# Altium schematic sheet esquematico-sensor-AD5933.SchDoc
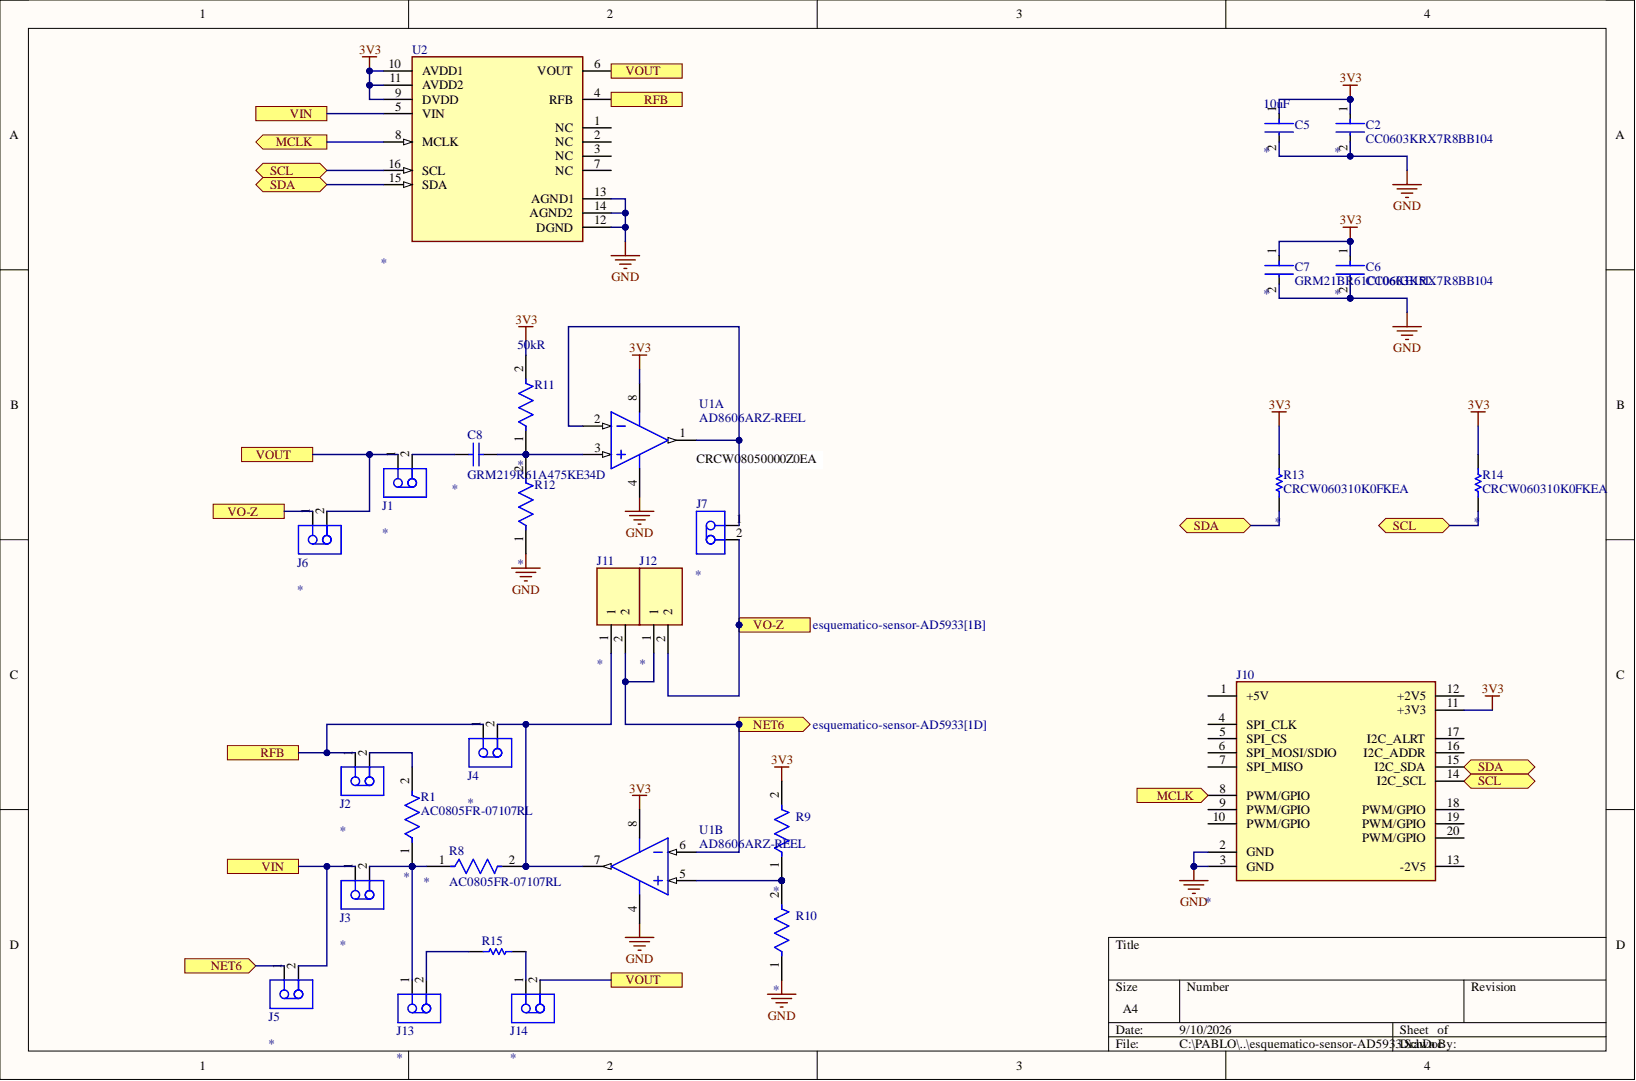
[diagram p0: esquematico-sensor-AD5933.SchDoc]

## Parts
C2  CC0603KRX7R8BB104  [FP-CC0603-DA-MFG]
C5  GRM21BR61C106KE15L  Capacitance: 10uF  [FP-GRM21B-0_1-IPC_C]
C6  CC0603KRX7R8BB104  [FP-CC0603-DA-MFG]
C7  GRM21BR61C106KE15L  [FP-GRM21B-0_1-IPC_C]
C8  GRM219R61A475KE34D  [CAPC2013X95X45LL10T25]
J1  (no value)  [TECO-640456-2_V]
J2  (no value)  [TECO-640456-2_V]
J3  (no value)  [TECO-640456-2_V]
J4  (no value)  [TECO-640456-2_V]
J5  (no value)  [TECO-640456-2_V]
J6  (no value)  [TECO-640456-2_V]
J7  (no value)  [TECO-640456-2_V]
J10  10129382-920002BLF  [Base Board Modular Connector (MALE)]  (value not drawn)
J11  (no value)  [Terminal Block 2-position]
J12  TB0011-350-02BE  [Terminal Block 2-position]  (value not drawn)
J13  (no value)  [TECO-640456-2_V]
J14  (no value)  [TECO-640456-2_V]
R1  AC0805FR-07107RL  [FP-AC0805-MFG]
R8  AC0805FR-07107RL  [FP-AC0805-MFG]
R9  (no value)  [FP-TNPU0603-e3-MFG]
R10  (no value)  [FP-TNPU0603-e3-MFG]
R11  Resistance: 50kR  [FP-TNPU0603-e3-MFG]
R12  (no value)  [FP-TNPU0603-e3-MFG]
R13  CRCW060310K0FKEA  [RESC1609X50X30NL8T20]
R14  CRCW060310K0FKEA  [RESC1609X50X30NL8T20]
R15  CRCW08050000Z0EA  [RESC2013X50X30LL20T20]
U1  AD8606ARZ-REEL  [ADI-R-8_L]  (parts A,B)
U2  AD5933WYRSZ-REEL7  [FP-RS-16-IPC_A]  (value not drawn)

## Ports
MCLK, NET6, RFB, SCL, SDA, VIN, VO-Z, VOUT

## Nets
3V3: C2.1 C5.1 C6.1 C7.1 J10.11(+3V3) R9.2 R11.2 R13.1 R14.2 U1.8 U2.9(DVDD) U2.10(AVDD1) U2.11(AVDD2)
GND: C2.2 C5.2 C6.2 C7.2 J10.2(GND) J10.3(GND) R10.1 R12.1 U1.4 U2.12(DGND) U2.13(AGND1) U2.14(AGND2)
MCLK: J10.8(PWM/GPIO) U2.8(MCLK) ; port MCLK
NET6: J5.1 J11.2 J12.1 U1.6 ; port NET6
RFB: J2.1 J4.1 U2.4(RFB) ; port RFB
SCL: J10.14(I2C_SCL) R14.1 U2.16(SCL) ; port SCL
SDA: J10.15(I2C_SDA) R13.2 U2.15(SDA) ; port SDA
VIN: J3.1 J5.2 U2.5(VIN) ; port VIN
VO-Z: J6.1 J7.2 J12.2 ; port VO-Z
VOUT: J1.1 J6.2 J14.2 U2.6(VOUT) ; port VOUT
NetC8_1: C8.1 J1.2
NetC8_2: C8.2 R11.1 R12.2 U1.3
NetJ2_2: J2.2 R1.2
NetJ3_2: J3.2 J13.1 R1.1 R8.1
NetJ4_2: J4.2 J11.1 R8.2 U1.7
NetJ7_1: J7.1 U1.1 U1.2
NetJ13_2: J13.2 R15.1
NetJ14_1: J14.1 R15.2
NetR9_1: R9.1 R10.2 U1.5

Not connected: J10 1(+5V) 4(SPI_CLK) 5(SPI_CS) 6(SPI_MOSI/SDIO) 7(SPI_MISO) 9(PWM/GPIO) 10(PWM/GPIO) 12(+2V5) 13(-2V5) 16(I2C_ADDR) 17(I2C_ALRT) 18(PWM/GPIO) 19(PWM/GPIO) 20(PWM/GPIO); U2 1(NC) 2(NC) 3(NC) 7(NC)

## Library data of the parts
C2 C6 = CMP-03422-000234-1: CAP CER 0.1UF 25V X7R 0603; Manufacturer: YAGEO; Capacitance: 100 nF; Material: Ceramic; Temperature Coefficient: 15%; Tolerance: 0.1; Value: 100 nF; Automotive: No; Category: Cap; Component Kind: Standard; ComponentLink2Description: Datasheet; ComponentLink2URL: http://www.yageo.com/documents/recent/UPY-GPHC_X7R_6.3V-to-50V_18.pdf; Device Class L1: Passive Components; Device Class L2: Capacitors; Device Class L3: Ceramic Capacitors; Digikey Description: CAP CER 0.1UF 25V X7R 0603; Footprint Url: http://www.yageo.com/exep/pages/download/literatures/UPY-C_GEN_24.pdf; Height: 0.9mm; Lead Free: Yes; Library Name: Altium Content Vault; Manufacturer Part Number: CC0603KRX7R8BB104; Mouser Description: CC Series 0603 0.1 uF 25 V ±10% Tolerance X7R SMT Multilayer Ceramic Capacitor; Octopart: https://octopart.com/cc0603krx7r8bb104-yageo-8376534; Package: CC0603-DA; RoHS: TRUE; Temperature: -55°C to +125°C; Temperature Characteristic: X7R; Temperature Range High: +125°C; Temperature Range Low: -55°C; Voltage: 25 V; Voltage Rating: 25 V
C5 C7 = CMP-2007-03453-2: Chip Multilayer Ceramic Capacitors for General Purpose, 0805, 10uF, X5R, 15%, 10%, 16V; Case Code (Imperial): 0805; Case Code (Metric): 2012; Case/Package: 0805; Component Kind: Standard; Depth: 1.25mm; Dielectric: X5R; Height: 1.25mm; Lead Free: Lead Free; Length: 2mm; Library Name: Altium Content Vault; Material: Ceramic; Max Operating Temperature: 85°C; Min Operating Temperature: -55°C; Mount: Surface Mount; Package Quantity: 1; Packaging: Tape and Reel; Radiation Hardening: No; REACH SVHC: No SVHC; Termination: SMD/SMT; Thickness: 0.053inch; Tolerance: 10%; Voltage: 16V; Voltage Rating: 16V; Voltage Rating (DC): 16V; Width: 1.25mm
  C7: Capacitance: 10uF
C8 = CMP-2007-00178-1: Manufacturer: Murata; Component Kind: Standard; Library Name: Altium Content Vault; Part Number: GRM219R61A475KE34D
J1-J7 J13 J14 = CMP-2000-05417-1: Male Header, Pitch 2.54 mm, 1 x 2 Position, Height 10.49 mm, Tail Length 3.556 mm, -55 to 105 degC, RoHS, Bulk; Manufacturer: TE Connectivity; Agency Approvals: CSA,UL; Angle: Straight; Brand/Series: MTA-100Series; Color, Housing: White; Connector Type: MTA-100; Contact Centerline Spacing: 0.100    in.; Contact Termination Area Plating: Tin; Current, Rating: 5    A; Material, Contact: CopperAlloy; Material, Housing: Polyester; Mount Angle: Vertical; Mounting Hole Size: 0.04    "; Number of Contacts: 2; Pin Spacing: 0.1    "; Primary Type: InterconnectSystem; Special Features: Pinstrip; Standards: RoHSCompliant,ELVCompliant,UL94V-0; Temperature, Operating: -55to+105    degC; Voltage, Rating: 250    V; Color: White; Component Kind: Standard; Contact Mating Area Plating: Tin; Contact Plating: Tin; Contact Shape: Square; Datasheet URL: https://datasheet.ciiva.com/11746/0900766b80eb7fdd-11746365.pdf; Datasheet Version: Rev. W, 06/2004; Flammability Rating: UL94V-0; Length, Overall: 0.2    "; Length, Pin: 0.14    "; Length, Terminal Post: 0.14    in.; Library Name: Altium Content Vault; Manufacturer URL: http://www.te.com/; Mounting Type: PCMount; Number of Positions: 2; Number of Rows: 1; Size, Post: 0.025    in.; Termination: Solder; Type: PCBPlug
J10 = Base Board Modular Connector (MALE): Component Kind: Standard; Library Name: CEDRA_Connectors.IntLib
J11 J12 = TB0011-350-02BE: Fixed Terminal Blocks 2 Poles, Screw Type, Horizontal, 3.50 Pitch, 24-18 (AWG), Blue, Terminal Block Connector; Component Kind: Standard; Supplier 1: DigiKey; Supplier 2: Mouser; Supplier 3: Farnell; Supplier Part Number 1: 2223-TB0011-350-02BE-ND; Supplier Part Number 2: 179-TB0011-350-02BE; Supplier Part Number 3: TB0011-350-02BE; Library Name: CEDRA_Connectors.IntLib
R1 R8 = CMP-03412-037308-1: Res SMD 107 Ohm 1% 1/8W 0805; Manufacturer: Yageo; Automotive Grade: Grade 0; Resistance: 107Ω; Tolerance: 0.01; Value: 107Ω; Voltage Rating: 150V; Automotive: Yes; Category: Res; Component Kind: Standard; ComponentLink2Description: Datasheet; ComponentLink2URL: http://www.yageo.com/documents/recent/PYu-AC_51_RoHS_L_6.pdf; Composition: Thick Film; Device Class L1: Passive Components; Device Class L2: Resistors; Device Class L3: Chip SMD Resistors; Digikey Description: Res SMD 107 Ohm 1% 1/8W 0805; Footprint Url: http://www.yageo.com/exep/pages/download/literatures/PYu-R_Mount_10.pdf; Height: 0.6mm; Ipc Land Pattern Name: RESC200125X50; Lead Free: Yes; Library Name: Altium Content Vault; Manufacturer Part Number: AC0805FR-07107RL; Octopart: https://octopart.com/ac0805fr-07107rl-yageo-71367522; Package: AC0805; Power Rating: 1/8W; RoHS: TRUE; Series: AC; Temperature: -55°C to +155°C; Temperature Range High: +155°C; Temperature Range Low: -55°C
R9 R10 R12 = CMP-02407-013067-1: RES SMD 50K OHM 0.02% 1/10W 0603; Max Power Dissipation: 100mW; Resistance: 50kR; Temperature Coefficient: 5ppm/°C; Case Code (Imperial): 0603; Case Code (Metric): 1608; Case/Package: 0603; Component Kind: Standard; Features: Moisture Resistant; Library Name: Altium Content Vault; Max Operating Temperature: 125°C; Min Operating Temperature: -55°C; Number of Terminations: 2; Packaging: Cut Tape; Resistor Type: Thin Film; Tolerance: 0.02%
R11 = CMP-02407-013067-1: RES SMD 50K OHM 0.02% 1/10W 0603; Max Power Dissipation: 100mW; Temperature Coefficient: 5ppm/°C; Case Code (Imperial): 0603; Case Code (Metric): 1608; Case/Package: 0603; Component Kind: Standard; Features: Moisture Resistant; Library Name: Altium Content Vault; Max Operating Temperature: 125°C; Min Operating Temperature: -55°C; Number of Terminations: 2; Packaging: Cut Tape; Resistor Type: Thin Film; Tolerance: 0.02%
R13 R14 = CMP-1666-00005-4: Chip Resistor, 10 KOhm, +/- 1%, 100 mW, -55 to 155 degC, 0603 (1608 Metric), RoHS, Tape and Reel; Manufacturer: Vishay Semiconductor; Voltage Rating: 75 V; Component Kind: Standard; ComponentLink1Description: Manufacturer URL; ComponentLink1URL: http://www.vishay.com/; ComponentLink2Description: Datasheet; ComponentLink2URL: https://datasheet.ciiva.com/11809/0900766b80d98afc-11809037.pdf; DatasheetVersion: 06/2012; Height: 0.45 mm; Length: 1.55 mm; Library Name: Altium Content Vault; Max Operating Temperature: 155 degC; Min Operating Temperature: -55 degC; Mounting Technology: Surface Mount; Package Quantity: 5000; PackageDescription: 2-Pin Surface Mount Device, Body 1.575 x 0.85 mm; PackageReference: 0603; Packaging: Tape and Reel; REACH SVHC: Unknown; RoHSCompliant: true
R15 = CMP-2001-04896-1: Manufacturer: Vishay Dale; Component Kind: Standard; Library Name: Altium Content Vault; Part Number: CRCW08050000Z0EA
U1 = CMP-0384-01320-2: Double Channel Wide Bandwidth Operational Amplifier, 10 MHz BW, 5 V/us SR, Industrial, 8-pin SOIC (R-8), Reel; Generic Part Number: AD8606; Manufacturer: Analog Devices; Amplifiers Per Package: 2; Input Bias Current: 200fA; Input Offset Voltage: 20uV; Iq/Amp: 1.2mA; Slew Rate: 5V/us; Small Signal Bandwidth: 10MHz; V Span Max: 6V; V Span Min: 2.7V; Code_JEDEC: MS-012-AA; Component Kind: Standard; ComponentLink1Description: Manufacturer URL; ComponentLink1URL: http://www.analog.com/en/index.html; ComponentLink2Description: Datasheet; ComponentLink2URL: http://www.analog.com/static/imported-files/data_sheets/AD8605_8606_8608.pdf; ComponentLink3Description: Package Specification; ComponentLink3URL: http://www.analog.com/static/imported-files/packages/PKG_PDF/SOIC_Narrow%20(R)/R_8.pdf; ComponentLink4Description: Reference Circuit; ComponentLink4URL: http://www.analog.com/en/all-operational-amplifiers-op-amps/operational-amplifiers-op-amps/ad8606/products/product.html#product-recommendations; DatasheetVersion: Rev. L; Library Name: Altium Content Vault; Mounting Technology: Surface Mount; PackageDescription: 8-Pin Standard Small Outline Package, Body 3.9 x 4.9 mm, Pitch 1.27 mm; PackageReference: R-8; PackageVersion: 060506-A; PartNumber: AD8606ARZ-REEL; RevisionNote: Reference Circuit URL fixed
U2 = CMP-09111-000002-1: 12BIT 1 MSPS, IMPEDANCE CONVERTE; Accuracy: 0.5%; Frequency: 16.776MHz; Nominal Supply Current: 15mA; Resolution: 12b; Sampling Rate: 1MS/s; Component Kind: Standard; Lead Free: Contains Lead; Library Name: Altium Content Vault; Number of Pins: 16; Operating Supply Current: 15mA; RoHS Compliant: Yes
